# Altium schematic sheet Connector_0.SchDoc
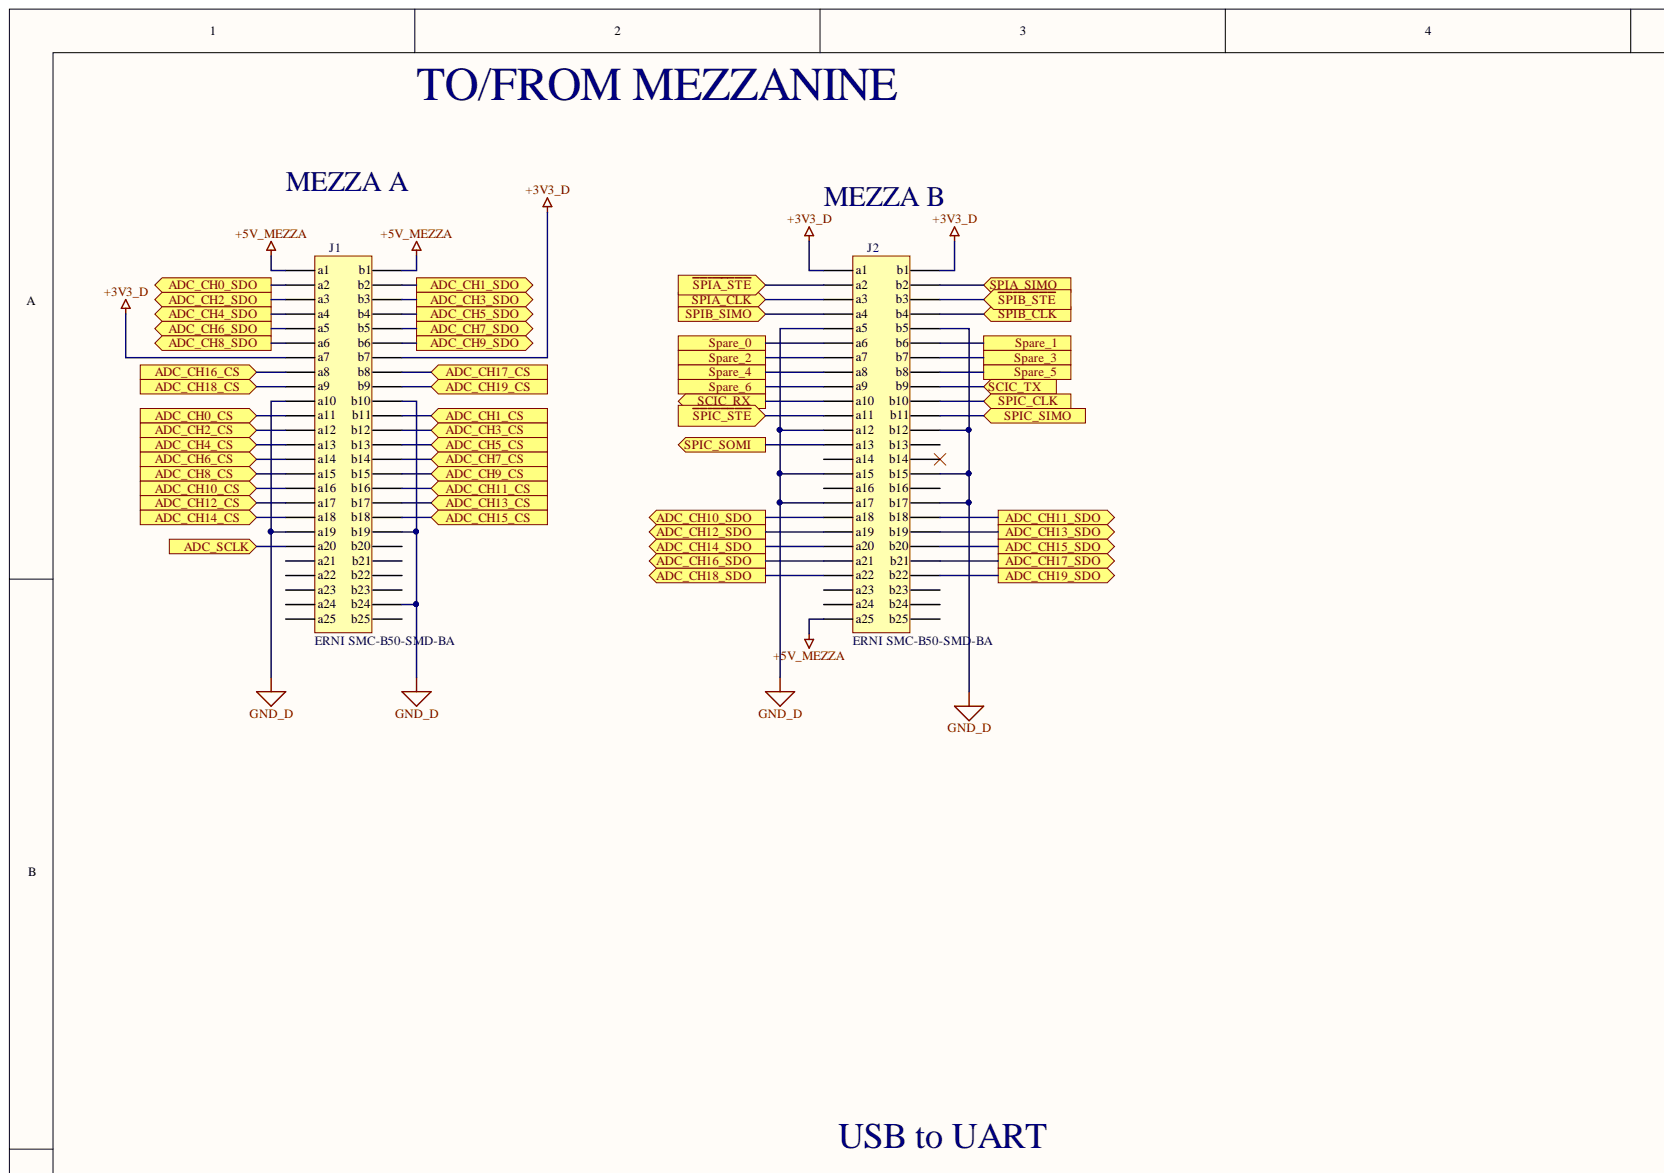
[diagram p0: Connector_0.SchDoc, top left]
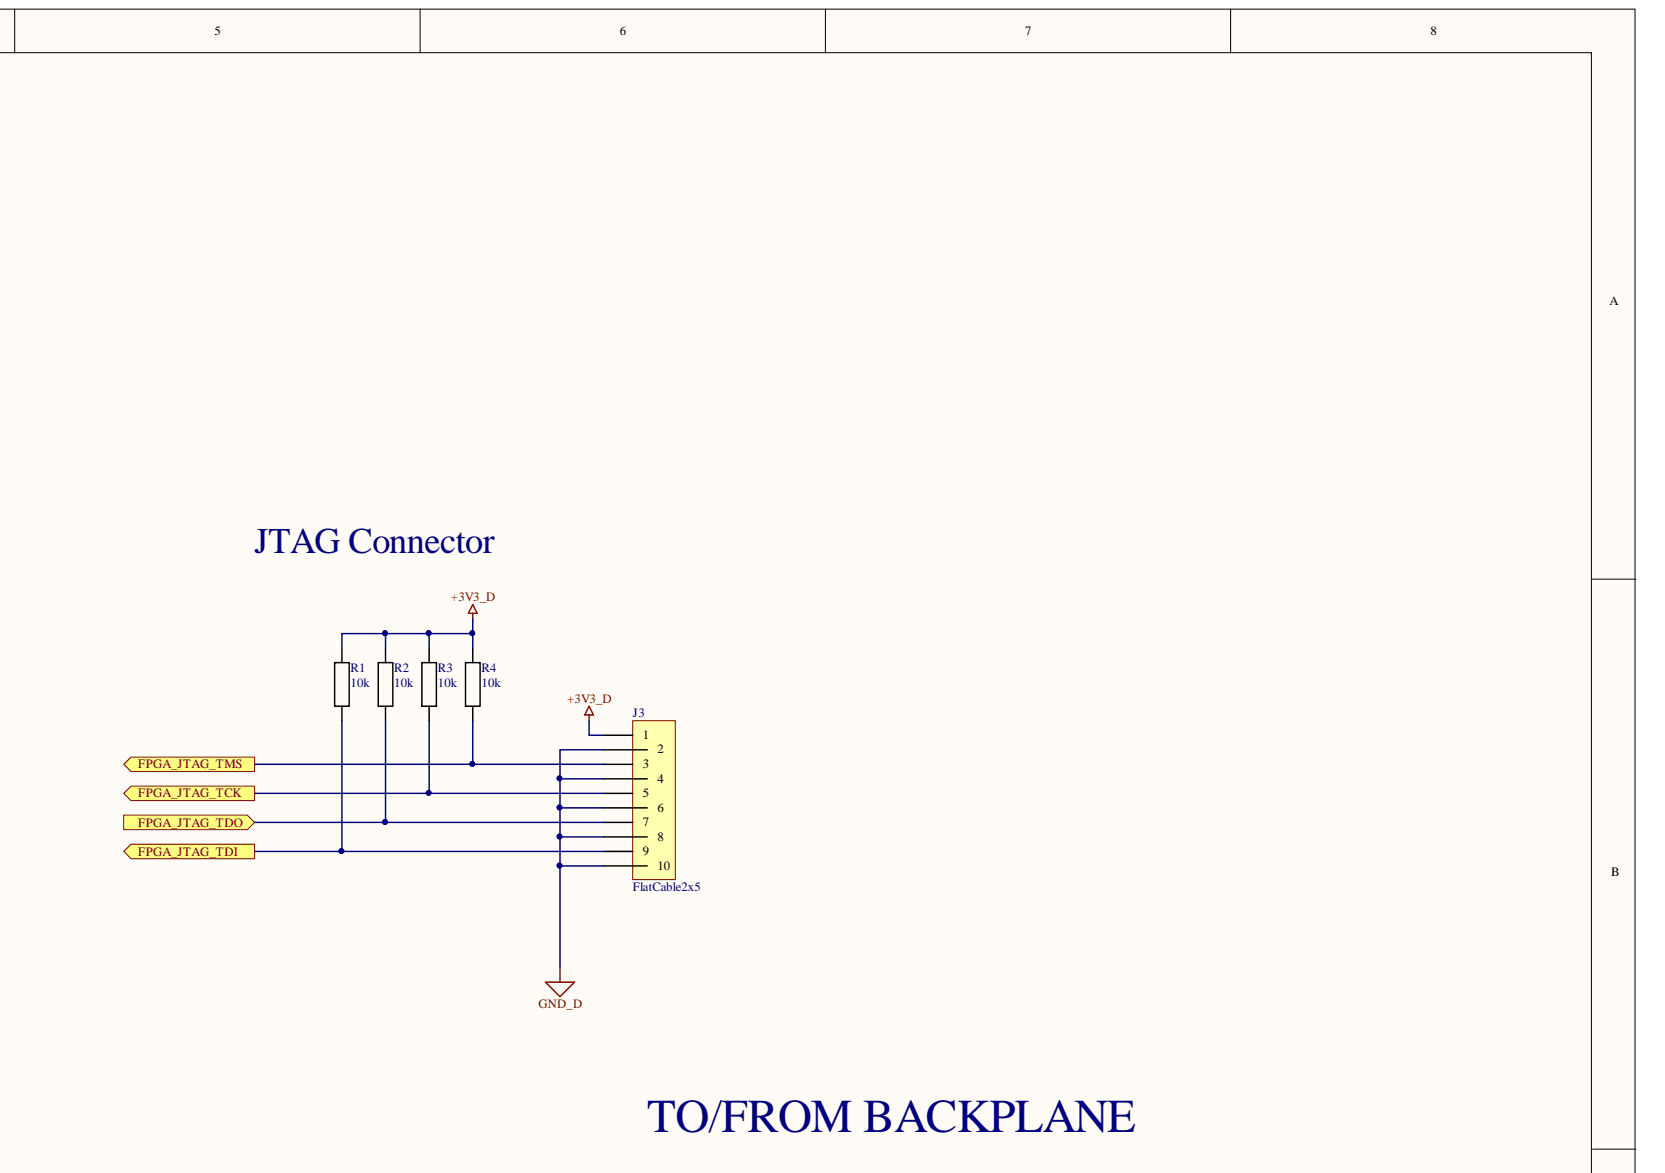
[diagram p1: Connector_0.SchDoc, top right]
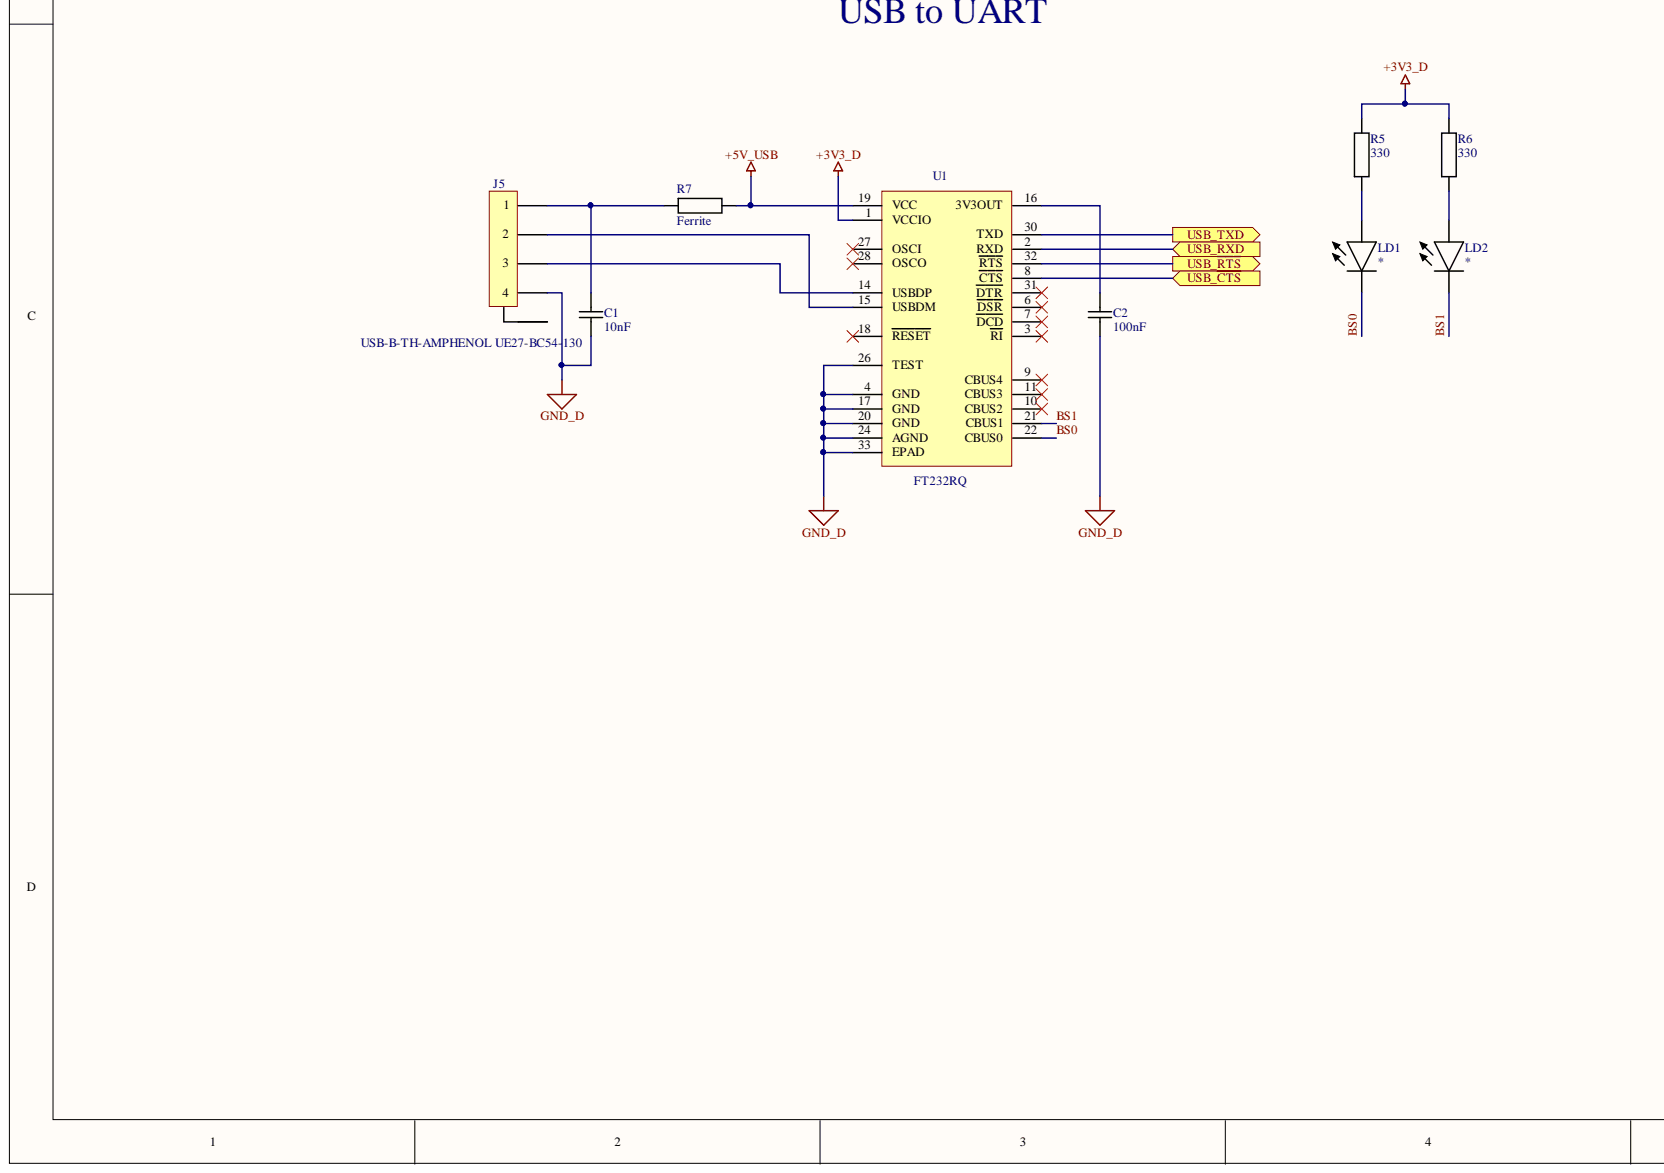
[diagram p2: Connector_0.SchDoc, bottom left]
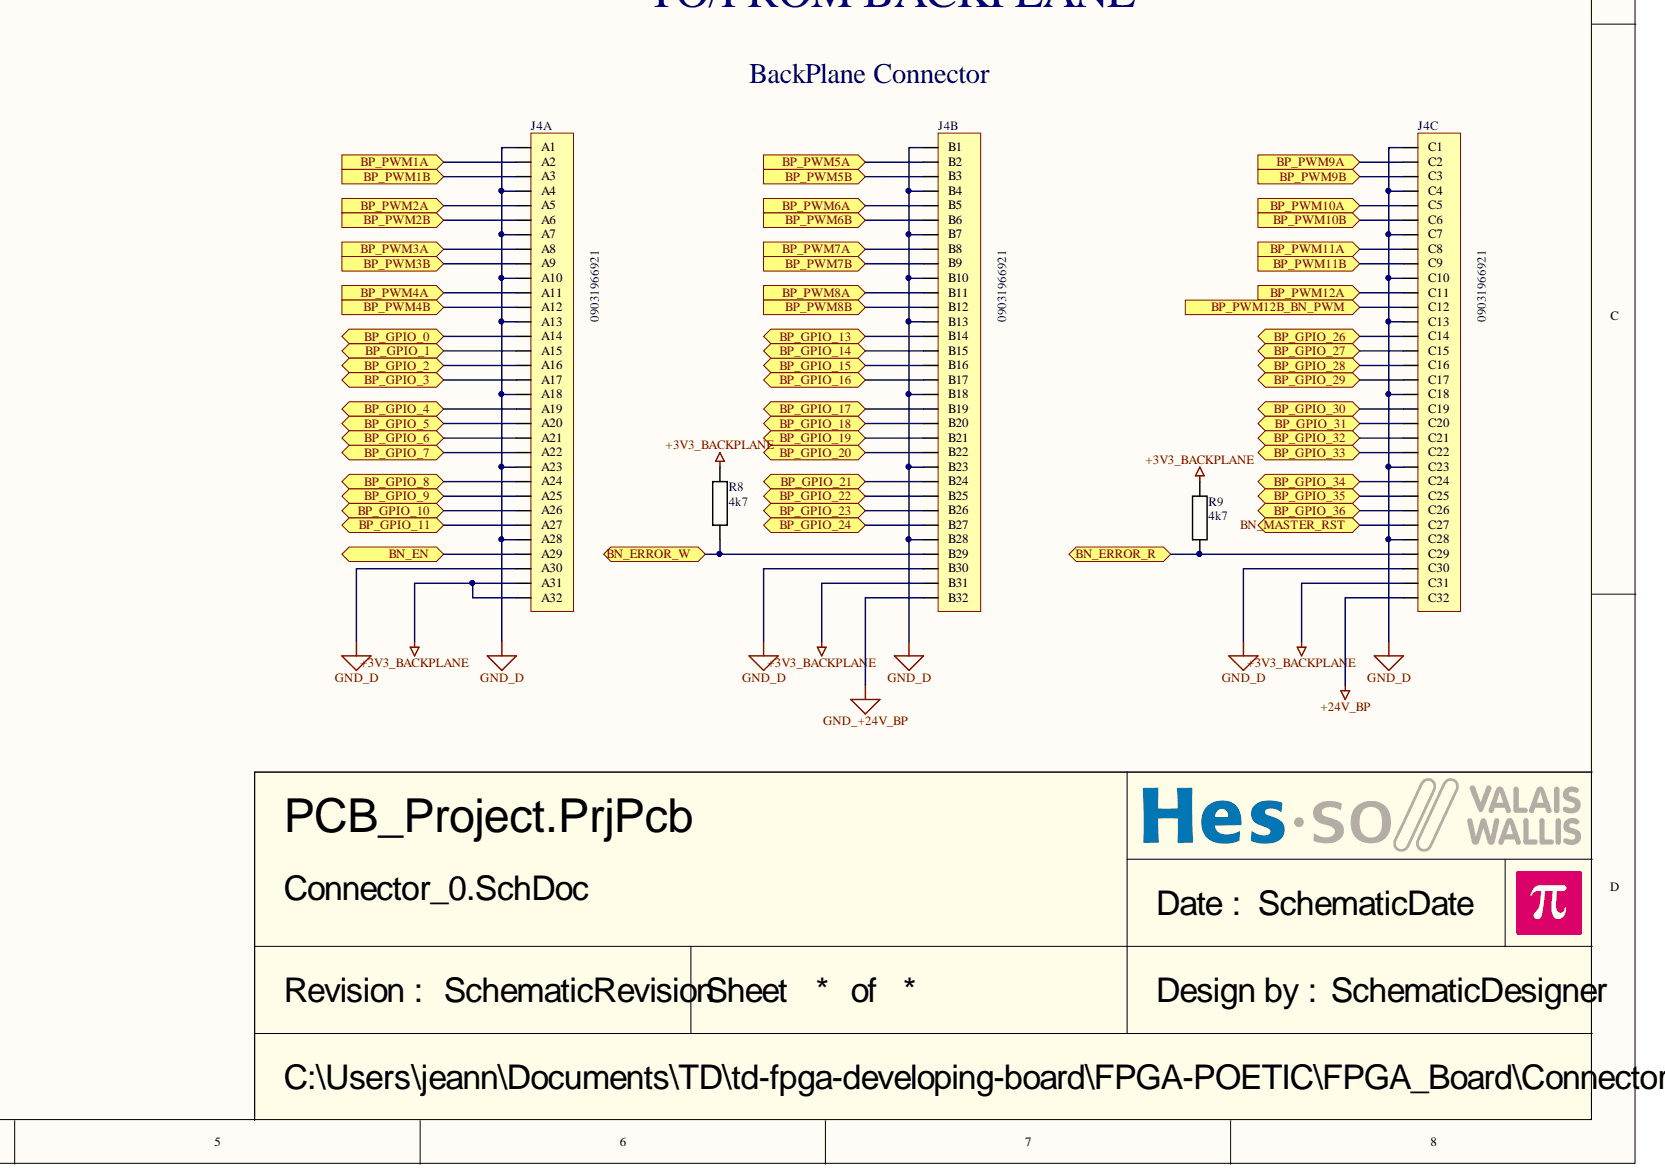
[diagram p3: Connector_0.SchDoc, bottom right]

## Parts
C1  10nF  [CAPACITOR 1608 REFLOW]
C2  100nF  [CAPACITOR 1608 REFLOW]
J1  ERNI SMC-B50-SMD-BA  [ERNI SMC-B50-SMD-BA]
J2  ERNI SMC-B50-SMD-BA  [ERNI SMC-B50-SMD-BA]
J3  FlatCable2x5  [FlatCable2x5 2MM Straight TH FCI 98414-G06-10ULF]
J4  09031966921  [DIN41612 MALE 3X32 ABC R90]  (parts A,B,C)
J5  USB-B-TH-AMPHENOL UE27-BC54-130  [USB-B TH AMPHENOL UE27-BC54-130]
LD1  (no value)  [LED 1608 REFLOW]
LD2  (no value)  [LED 1608 REFLOW]
R1  10k  [RESISTOR 1608 REFLOW]
R2  10k  [RESISTOR 1608 REFLOW]
R3  10k  [RESISTOR 1608 REFLOW]
R4  10k  [RESISTOR 1608 REFLOW]
R5  330  [RESISTOR 2012 REFLOW]
R6  330  [RESISTOR 2012 REFLOW]
R7  Ferrite  [RESISTOR 1608 REFLOW]
R8  4k7  [RESISTOR 1608 REFLOW]
R9  4k7  [RESISTOR 1608 REFLOW]
U1  FT232RQ  [QFN32 P0.5 T3.2x3.2 C5.0X5.0 H1.0]

## Ports
ADC_CH0_CS, ADC_CH0_SDO, ADC_CH1_CS, ADC_CH1_SDO, ADC_CH2_CS, ADC_CH2_SDO, ADC_CH3_CS, ADC_CH3_SDO, ADC_CH4_CS, ADC_CH4_SDO, ADC_CH5_CS, ADC_CH5_SDO, ADC_CH6_CS, ADC_CH6_SDO, ADC_CH7_CS, ADC_CH7_SDO, ADC_CH8_CS, ADC_CH8_SDO, ADC_CH9_CS, ADC_CH9_SDO, ADC_CH10_CS, ADC_CH10_SDO, ADC_CH11_CS, ADC_CH11_SDO, ADC_CH12_CS, ADC_CH12_SDO, ADC_CH13_CS, ADC_CH13_SDO, ADC_CH14_CS, ADC_CH14_SDO, ADC_CH15_CS, ADC_CH15_SDO, ADC_CH16_CS, ADC_CH16_SDO, ADC_CH17_CS, ADC_CH17_SDO, ADC_CH18_CS, ADC_CH18_SDO, ADC_CH19_CS, ADC_CH19_SDO, ADC_SCLK, BN_EN, BN_ERROR_R, BN_ERROR_W, BN_MASTER_RST, BP_GPIO_0, BP_GPIO_1, BP_GPIO_2, BP_GPIO_3, BP_GPIO_4, BP_GPIO_5, BP_GPIO_6, BP_GPIO_7, BP_GPIO_8, BP_GPIO_9, BP_GPIO_10, BP_GPIO_11, BP_GPIO_13, BP_GPIO_14, BP_GPIO_15, BP_GPIO_16, BP_GPIO_17, BP_GPIO_18, BP_GPIO_19, BP_GPIO_20, BP_GPIO_21, BP_GPIO_22, BP_GPIO_23, BP_GPIO_24, BP_GPIO_26, BP_GPIO_27, BP_GPIO_28, BP_GPIO_29, BP_GPIO_30, BP_GPIO_31, BP_GPIO_32, BP_GPIO_33, BP_GPIO_34, BP_GPIO_35, BP_GPIO_36, BP_PWM1A, BP_PWM1B, BP_PWM2A, BP_PWM2B, BP_PWM3A, BP_PWM3B, BP_PWM4A, BP_PWM4B, BP_PWM5A, BP_PWM5B, BP_PWM6A, BP_PWM6B, BP_PWM7A, BP_PWM7B, BP_PWM8A, BP_PWM8B, BP_PWM9A, BP_PWM9B, BP_PWM10A, BP_PWM10B, BP_PWM11A, BP_PWM11B, BP_PWM12A, BP_PWM12B_BN_PWM, FPGA_JTAG_TCK, FPGA_JTAG_TDI, FPGA_JTAG_TDO, FPGA_JTAG_TMS, SCIC_RX, SCIC_TX, Spare_0, Spare_1, Spare_2, Spare_3, Spare_4, Spare_5, Spare_6, SPIA_CLK, SPIA_SIMO, SPIB_CLK, SPIB_SIMO, SPIC_CLK, SPIC_SIMO, SPIC_SOMI, USB_RXD, USB_TXD, USB_~{CTS}, USB_~{RTS}, ~{SPIA_STE}, ~{SPIB_STE}, ~{SPIC_STE}

## Nets
+3V3_BACKPLANE: J4.A31 J4.A32 J4.B31 J4.C31 R8.2 R9.2
+3V3_D: J1.a7 J1.b7 J2.a1 J2.b1 J3.1 R1.2 R2.2 R3.2 R4.2 R5.2 R6.2 U1.1(VCCIO)
+5V_MEZZA: J1.a1 J1.b1 J2.a25
+5V_USB: R7.2 U1.19(VCC)
+24V_BP: J4.C32
GND_+24V_BP: J4.B32
GND_D: C1.1 C2.1 J1.a10 J1.a19 J1.b10 J1.b19 J1.b24 J2.a5 J2.a12 J2.a15 J2.a17 J2.b5 J2.b12 J2.b15 J2.b17 J3.2 J3.4 J3.6 J3.8 J3.10 J4.A1 J4.A4 J4.A7 J4.A10 J4.A13 J4.A18 J4.A23 J4.A28 J4.A30 J4.B1 J4.B4 J4.B7 J4.B10 J4.B13 J4.B18 J4.B23 J4.B28 J4.B30 J4.C1 J4.C4 J4.C7 J4.C10 J4.C13 J4.C18 J4.C23 J4.C28 J4.C30 J5.4 U1.4(GND) U1.17(GND) U1.20(GND) U1.24(AGND) U1.26(TEST) U1.33(EPAD)
~{SPIA_STE}: J2.a2 ; port ~{SPIA_STE}
~{SPIB_STE}: J2.b3 ; port ~{SPIB_STE}
~{SPIC_STE}: J2.a11 ; port ~{SPIC_STE}
ADC_CH0_CS: J1.a11 ; port ADC_CH0_CS
ADC_CH0_SDO: J1.a2 ; port ADC_CH0_SDO
ADC_CH1_CS: J1.b11 ; port ADC_CH1_CS
ADC_CH1_SDO: J1.b2 ; port ADC_CH1_SDO
ADC_CH2_CS: J1.a12 ; port ADC_CH2_CS
ADC_CH2_SDO: J1.a3 ; port ADC_CH2_SDO
ADC_CH3_CS: J1.b12 ; port ADC_CH3_CS
ADC_CH3_SDO: J1.b3 ; port ADC_CH3_SDO
ADC_CH4_CS: J1.a13 ; port ADC_CH4_CS
ADC_CH4_SDO: J1.a4 ; port ADC_CH4_SDO
ADC_CH5_CS: J1.b13 ; port ADC_CH5_CS
ADC_CH5_SDO: J1.b4 ; port ADC_CH5_SDO
ADC_CH6_CS: J1.a14 ; port ADC_CH6_CS
ADC_CH6_SDO: J1.a5 ; port ADC_CH6_SDO
ADC_CH7_CS: J1.b14 ; port ADC_CH7_CS
ADC_CH7_SDO: J1.b5 ; port ADC_CH7_SDO
ADC_CH8_CS: J1.a15 ; port ADC_CH8_CS
ADC_CH8_SDO: J1.a6 ; port ADC_CH8_SDO
ADC_CH9_CS: J1.b15 ; port ADC_CH9_CS
ADC_CH9_SDO: J1.b6 ; port ADC_CH9_SDO
ADC_CH10_CS: J1.a16 ; port ADC_CH10_CS
ADC_CH10_SDO: J2.a18 ; port ADC_CH10_SDO
ADC_CH11_CS: J1.b16 ; port ADC_CH11_CS
ADC_CH11_SDO: J2.b18 ; port ADC_CH11_SDO
ADC_CH12_CS: J1.a17 ; port ADC_CH12_CS
ADC_CH12_SDO: J2.a19 ; port ADC_CH12_SDO
ADC_CH13_CS: J1.b17 ; port ADC_CH13_CS
ADC_CH13_SDO: J2.b19 ; port ADC_CH13_SDO
ADC_CH14_CS: J1.a18 ; port ADC_CH14_CS
ADC_CH14_SDO: J2.a20 ; port ADC_CH14_SDO
ADC_CH15_CS: J1.b18 ; port ADC_CH15_CS
ADC_CH15_SDO: J2.b20 ; port ADC_CH15_SDO
ADC_CH16_CS: J1.a8 ; port ADC_CH16_CS
ADC_CH16_SDO: J2.a21 ; port ADC_CH16_SDO
ADC_CH17_CS: J1.b8 ; port ADC_CH17_CS
ADC_CH17_SDO: J2.b21 ; port ADC_CH17_SDO
ADC_CH18_CS: J1.a9 ; port ADC_CH18_CS
ADC_CH18_SDO: J2.a22 ; port ADC_CH18_SDO
ADC_CH19_CS: J1.b9 ; port ADC_CH19_CS
ADC_CH19_SDO: J2.b22 ; port ADC_CH19_SDO
ADC_SCLK: J1.a20 ; port ADC_SCLK
BN_EN: J4.A29 ; port BN_EN
BN_ERROR_R: J4.C29 R9.1 ; port BN_ERROR_R
BN_ERROR_W: J4.B29 R8.1 ; port BN_ERROR_W
BN_MASTER_RST: J4.C27 ; port BN_MASTER_RST
BP_GPIO_0: J4.A14 ; port BP_GPIO_0
BP_GPIO_1: J4.A15 ; port BP_GPIO_1
BP_GPIO_2: J4.A16 ; port BP_GPIO_2
BP_GPIO_3: J4.A17 ; port BP_GPIO_3
BP_GPIO_4: J4.A19 ; port BP_GPIO_4
BP_GPIO_5: J4.A20 ; port BP_GPIO_5
BP_GPIO_6: J4.A21 ; port BP_GPIO_6
BP_GPIO_7: J4.A22 ; port BP_GPIO_7
BP_GPIO_8: J4.A24 ; port BP_GPIO_8
BP_GPIO_9: J4.A25 ; port BP_GPIO_9
BP_GPIO_10: J4.A26 ; port BP_GPIO_10
BP_GPIO_11: J4.A27 ; port BP_GPIO_11
BP_GPIO_13: J4.B14 ; port BP_GPIO_13
BP_GPIO_14: J4.B15 ; port BP_GPIO_14
BP_GPIO_15: J4.B16 ; port BP_GPIO_15
BP_GPIO_16: J4.B17 ; port BP_GPIO_16
BP_GPIO_17: J4.B19 ; port BP_GPIO_17
BP_GPIO_18: J4.B20 ; port BP_GPIO_18
BP_GPIO_19: J4.B21 ; port BP_GPIO_19
BP_GPIO_20: J4.B22 ; port BP_GPIO_20
BP_GPIO_21: J4.B24 ; port BP_GPIO_21
BP_GPIO_22: J4.B25 ; port BP_GPIO_22
BP_GPIO_23: J4.B26 ; port BP_GPIO_23
BP_GPIO_24: J4.B27 ; port BP_GPIO_24
BP_GPIO_26: J4.C14 ; port BP_GPIO_26
BP_GPIO_27: J4.C15 ; port BP_GPIO_27
BP_GPIO_28: J4.C16 ; port BP_GPIO_28
BP_GPIO_29: J4.C17 ; port BP_GPIO_29
BP_GPIO_30: J4.C19 ; port BP_GPIO_30
BP_GPIO_31: J4.C20 ; port BP_GPIO_31
BP_GPIO_32: J4.C21 ; port BP_GPIO_32
BP_GPIO_33: J4.C22 ; port BP_GPIO_33
BP_GPIO_34: J4.C24 ; port BP_GPIO_34
BP_GPIO_35: J4.C25 ; port BP_GPIO_35
BP_GPIO_36: J4.C26 ; port BP_GPIO_36
BP_PWM1A: J4.A2 ; port BP_PWM1A
BP_PWM1B: J4.A3 ; port BP_PWM1B
BP_PWM2A: J4.A5 ; port BP_PWM2A
BP_PWM2B: J4.A6 ; port BP_PWM2B
BP_PWM3A: J4.A8 ; port BP_PWM3A
BP_PWM3B: J4.A9 ; port BP_PWM3B
BP_PWM4A: J4.A11 ; port BP_PWM4A
BP_PWM4B: J4.A12 ; port BP_PWM4B
BP_PWM5A: J4.B2 ; port BP_PWM5A
BP_PWM5B: J4.B3 ; port BP_PWM5B
BP_PWM6A: J4.B5 ; port BP_PWM6A
BP_PWM6B: J4.B6 ; port BP_PWM6B
BP_PWM7A: J4.B8 ; port BP_PWM7A
BP_PWM7B: J4.B9 ; port BP_PWM7B
BP_PWM8A: J4.B11 ; port BP_PWM8A
BP_PWM8B: J4.B12 ; port BP_PWM8B
BP_PWM9A: J4.C2 ; port BP_PWM9A
BP_PWM9B: J4.C3 ; port BP_PWM9B
BP_PWM10A: J4.C5 ; port BP_PWM10A
BP_PWM10B: J4.C6 ; port BP_PWM10B
BP_PWM11A: J4.C8 ; port BP_PWM11A
BP_PWM11B: J4.C9 ; port BP_PWM11B
BP_PWM12A: J4.C11 ; port BP_PWM12A
BP_PWM12B_BN_PWM: J4.C12 ; port BP_PWM12B_BN_PWM
BS0: LD1.1 U1.22(CBUS0)
BS1: LD2.1 U1.21(CBUS1)
FPGA_JTAG_TCK: J3.5 R3.1 ; port FPGA_JTAG_TCK
FPGA_JTAG_TDI: J3.9 R1.1 ; port FPGA_JTAG_TDI
FPGA_JTAG_TDO: J3.7 R2.1 ; port FPGA_JTAG_TDO
FPGA_JTAG_TMS: J3.3 R4.1 ; port FPGA_JTAG_TMS
SCIC_RX: J2.a10 ; port SCIC_RX
SCIC_TX: J2.b9 ; port SCIC_TX
Spare_0: J2.a6 ; port Spare_0
Spare_1: J2.b6 ; port Spare_1
Spare_2: J2.a7 ; port Spare_2
Spare_3: J2.b7 ; port Spare_3
Spare_4: J2.a8 ; port Spare_4
Spare_5: J2.b8 ; port Spare_5
Spare_6: J2.a9 ; port Spare_6
SPIA_CLK: J2.a3 ; port SPIA_CLK
SPIA_SIMO: J2.b2 ; port SPIA_SIMO
SPIB_CLK: J2.b4 ; port SPIB_CLK
SPIB_SIMO: J2.a4 ; port SPIB_SIMO
SPIC_CLK: J2.b10 ; port SPIC_CLK
SPIC_SIMO: J2.b11 ; port SPIC_SIMO
SPIC_SOMI: J2.a13 ; port SPIC_SOMI
USB_~{CTS}: U1.8(CTS) ; port USB_~{CTS}
USB_~{RTS}: U1.32(RTS) ; port USB_~{RTS}
USB_RXD: U1.2(RXD) ; port USB_RXD
USB_TXD: U1.30(TXD) ; port USB_TXD
NetC1_2: C1.2 J5.1 R7.1
NetC2_2: C2.2 U1.16(3V3OUT)
NetJ5_2: J5.2 U1.15(USBDM)
NetJ5_3: J5.3 U1.14(USBDP)
NetJ5_5: J5.5 J5.6
NetLD1_2: LD1.2 R5.1
NetLD2_2: LD2.2 R6.1
N00417:

Not connected: J1 a21 a22 a23 a24 a25 b20 b21 b22 b23 b25; J2 a14 a16 a23 a24 b13 b14 b16 b23 b24 b25; U1 3(RI) 6(DSR) 7(DCD) 9(CBUS4) 10(CBUS2) 11(CBUS3) 18(RESET) 27(OSCI) 28(OSCO) 31(DTR)

## Library data of the parts
C1 C2 = Capacitor: Capacitor X7R 10% 50V 1608(0603); Component Kind: Standard; Library Name: Capacitor.IntLib
  C1: Value: 10nF
  C2: Value: 100nF
J1 J2 = ERNI SMC-B50-SMD-BA: Female 1.27 pitch 2x25 pin connector; Component Kind: Standard; Library Name: Connector.IntLib
J4 = DIN41612 MALE 3X32 ABC R90: Manufacturer: Harting; Component Kind: Standard; Description: DIN41612 MALE 3X32 ABC R90; Library Name: Connector.IntLib; Mouser No.: 617-09-03-196-6921; Value: 09031966921
LD1 LD2 = LED: LED generic; Component Kind: Standard; Library Name: Diode.IntLib
R1-R4 R7-R9 = Resistor: Resistor 1% 50V 100mW 1608(0603); Component Kind: Standard; Library Name: Resistor.IntLib
  R1-R4: Value: 10k
  R7: Value: Ferrite
  R8 R9: Value: 4k7
R5 R6 = Resistor: Resistor 1% 50V 125mW 2012(0805); Component Kind: Standard; Library Name: Resistor.IntLib; Value: 330
U1 = FT232RQ: 24-Bit ADC with Ultra Low Noise PGIA - Crystal; Component Kind: Standard; Library Name: IntegratedCircuit - Copy.IntLib; Value: FT232RQ
J3 = FlatCable2x5: Component Kind: Standard; Description: FlatCable2x5; Library Name: Connector.IntLib
J5 = USB-B-TH-AMPHENOL UE27-BC54-130: Component Kind: Standard; Description: USB-B-TH-AMPHENOL UE27-BC54-130; Library Name: Connector.IntLib
